# Altium schematic sheet 02_ESP12F_CORE.SchDoc(02_ESP12F_CORE)
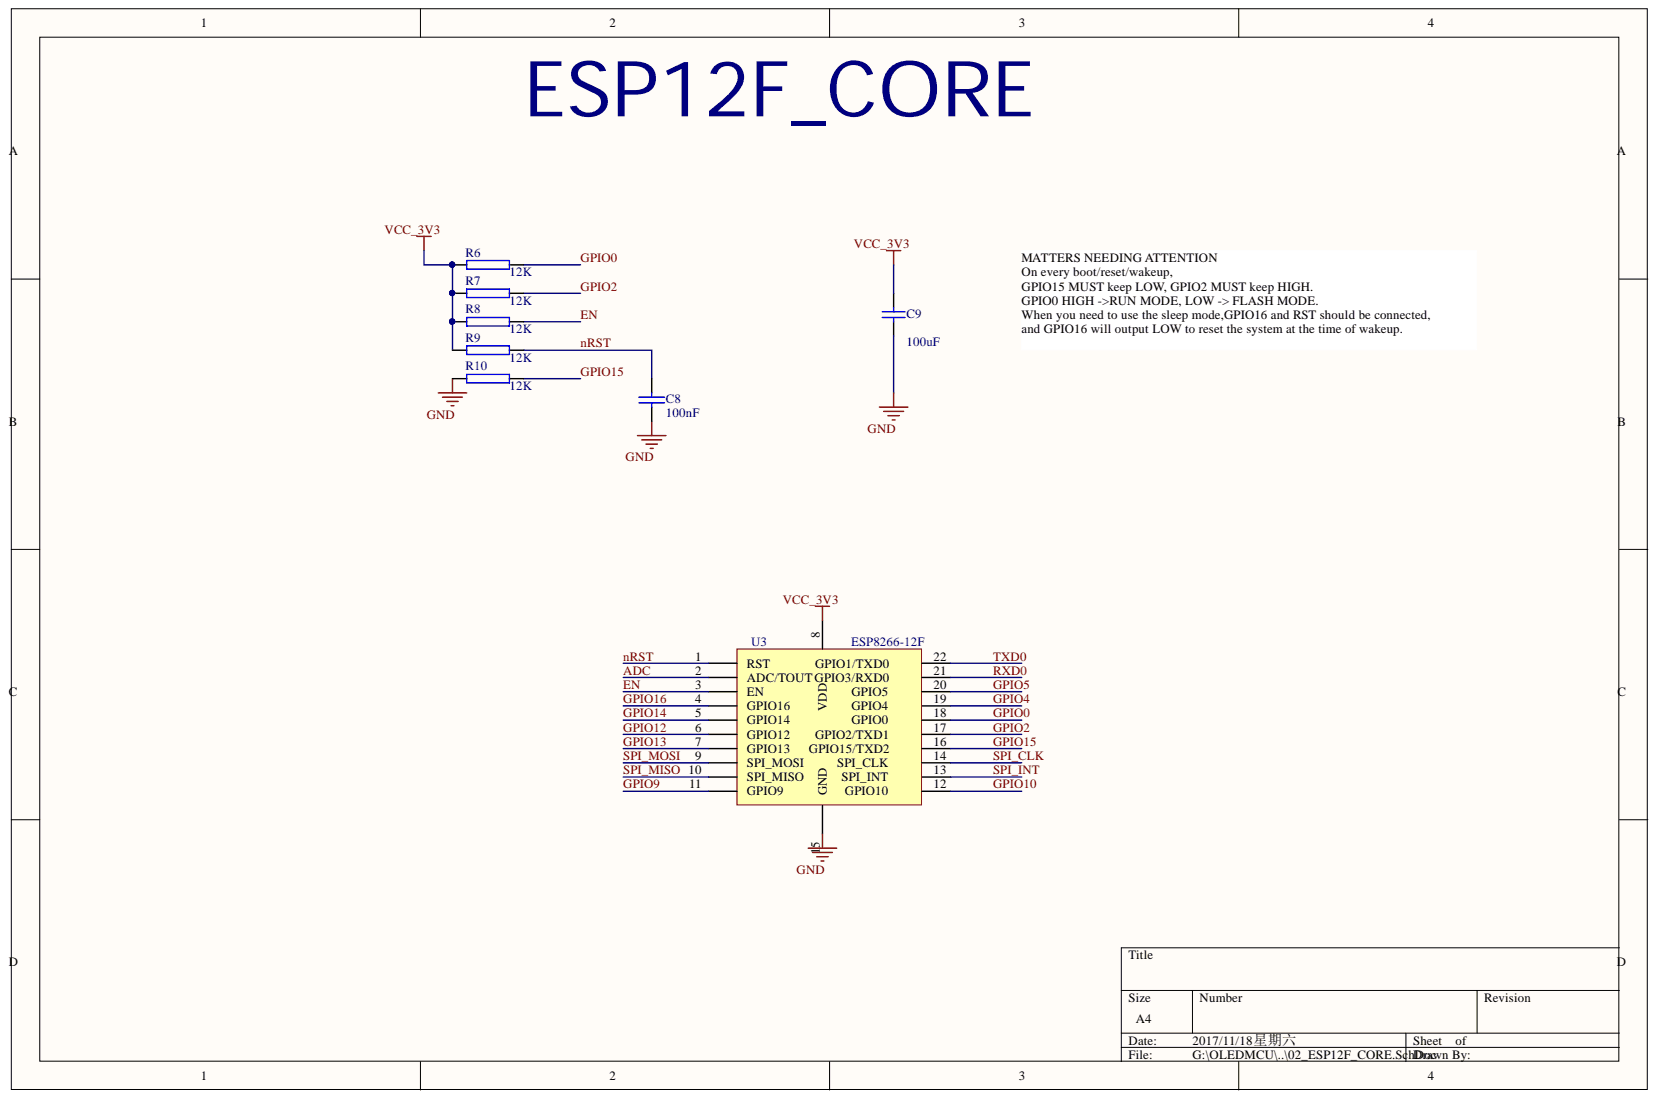
[diagram p0: 02_ESP12F_CORE.SchDoc]

## Parts
C8  100nF  [C0603]
C9  100uF  [C3528]
R6  12K  [R0603]
R7  12K  [R0603]
R8  12K  [R0603]
R9  12K  [R0603]
R10  12K  [R0603]
U3  ESP8266-12F  [esp8266-12f]

## Nets
GND: C8.2 C9.2 R10.1 U3.15(GND)
VCC_3V3: C9.1 R6.1 R7.1 R8.1 R9.1 U3.8
ADC: U3.2
EN: R8.2 U3.3(EN)
GPIO0: R6.2 U3.18
GPIO2: R7.2 U3.17
GPIO4: U3.19
GPIO5: U3.20
GPIO9: U3.11(GPIO9)
GPIO10: U3.12
GPIO12: U3.6(GPIO12)
GPIO13: U3.7(GPIO13)
GPIO14: U3.5(GPIO14)
GPIO15: R10.2 U3.16
GPIO16: U3.4(GPIO16)
NRST: C8.1 R9.2 U3.1(RST)
RXD0: U3.21
SPI_CLK: U3.14
SPI_INT: U3.13
SPI_MISO: U3.10(SPI_MISO)
SPI_MOSI: U3.9(SPI_MOSI)
TXD0: U3.22

## Library data of the parts
C8 = C0603: 贴片电容; Component Kind: Standard; Library Name: Schlib_OLEDMCU.SchLib
C9 = Cap Semi: Capacitor 3.5x2.8mm; Component Kind: Standard; LatestRevisionDate: 29-May-2009; LatestRevisionNote: IPC-7351 Footprint Added.; Library Name: Miscellaneous Devices.IntLib; PackageDescription: Chip Capacitor; PackageReference: C1206; Published: 8-Jun-2000; Signal Integrity: Cap Semi; Simulation: CAP; Value: 100uF; Publisher: Altium Limited
R6-R10 = R0603: 贴片电阻; Component Kind: Standard; Library Name: Schlib_OLEDMCU.SchLib
U3 = ESP8266-12F: WiFi芯片; Component Kind: Standard; Library Name: Schlib_OLEDMCU.SchLib
